# Altium schematic sheet MecanumTb66212.SchDoc
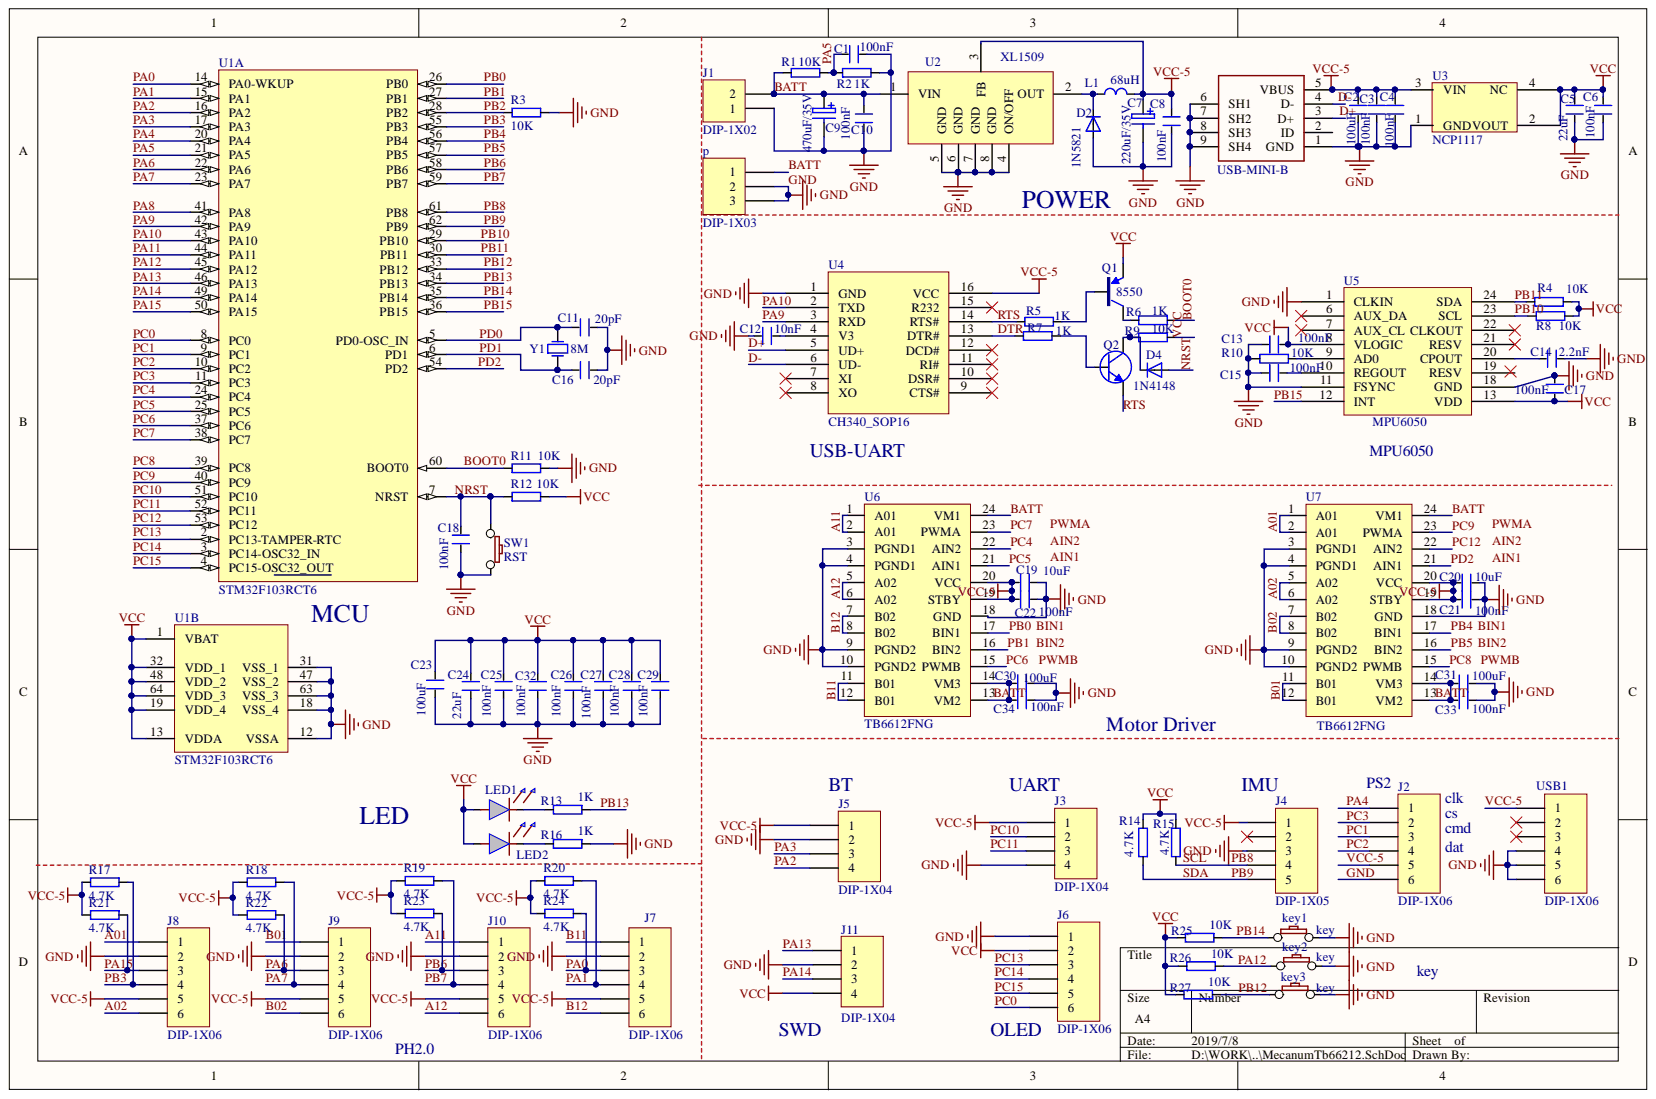
[diagram p0: MecanumTb66212.SchDoc]

## Parts
C1  100nF  [0603C]
C2  100uF  [1206C]
C3  100nF  [0603C]
C4  100nF  [0603C]
C5  22uF  [0805C]
C6  100nF  [0603C]
C7  220uF/35V  [C6.3X6.3]
C8  100nF  [0603C]
C9  470uF/35V  [C6.3X6.3]
C10  100nF  [0603C]
C11  20pF  [0603C]
C12  10nF  [0603C]
C13  100nF  [0603C]
C14  2.2nF  [0603C]
C15  100nF  [0603C]
C16  20pF  [0603C]
C17  100nF  [0603C]
C18  100nF  [0603C]
C19  10uF  [0603C]
C20  10uF  [0603C]
C21  100nF  [0603C]
C22  100nF  [0603C]
C23  100uF  [C6.3X6.3]
C24  22uF  [1206C]
C25  100nF  [0603C]
C26  100nF  [0603C]
C27  100nF  [0603C]
C28  100nF  [0603C]
C29  100nF  [0603C]
C30  100uF  [0805C]
C31  100uF  [0805C]
C32  100nF  [0603C]
C33  100nF  [0805C]
C34  100nF  [0805C]
D2  1N5821  [SMB]
D4  1N4148  [0603LED]
J1  DIP-1X02  [2PIN-5.08A]
J2  DIP-1X06  [HDR1X6]
J3  DIP-1X04  [HDR1X4]
J4  DIP-1X05  [DIP-1X05-2.54]
J5  DIP-1X04  [HDR1X4]
J6  DIP-1X06  [HDR1X6]
J7  DIP-1X06  [HDR1X6]
J8  DIP-1X06  [HDR1X6]
J9  DIP-1X06  [HDR1X6]
J10  DIP-1X06  [HDR1X6]
J11  DIP-1X04  [HDR1X4]
key1  key  [3.6*2.5-SWITCH]
key2  key  [3.6*2.5-SWITCH]
key3  key  [3.6*2.5-SWITCH]
L1  68uH  [L10X10]
LED1  RED_RX  [0603LED G]  (value not drawn)
LED2  RED_RX  [0603LED R]  (value not drawn)
p  DIP-1X03  [Power-Normal]
Q1  8550  [SOT23-3-TCM809]
Q2  8050  [AP2306]  (value not drawn)
R1  10K  [0603R]
R2  1K  [0603R]
R3  10K  [0603R]
R4  10K  [0603R]
R5  1K  [0603R]
R6  1K  [0603R]
R7  1K  [0603R]
R8  10K  [0603R]
R9  10K  [0603R]
R10  10K  [0603R]
R11  10K  [0603R]
R12  10K  [0603R]
R13  1K  [0603R]
R14  4.7K  [0603R]
R15  4.7K  [0603R]
R16  1K  [0603R]
R17  4.7K  [0603R]
R18  4.7K  [0603R]
R19  4.7K  [0603R]
R20  4.7K  [0603R]
R21  4.7K  [0603R]
R22  4.7K  [0603R]
R23  4.7K  [0603R]
R24  4.7K  [0603R]
R25  10K  [0603R]
R26  10K  [0603R]
R27  10K  [0603R]
SW1  RST  [3.6*2.5-SWITCH]
U1  STM32F103RCT6  [LC-LQFP-64_10x10x05P]  (parts A,B)
U2  XL1509  [SOP8]
U3  NCP1117  [SOT223-NCP1117]
U4  CH340_SOP16  [SOP16-MAX3232]
U5  MPU6050  [QFN24-MPU6050]
U6  TB6612FNG  [SSOP24]
U7  TB6612FNG  [SSOP24]
USB1  DIP-1X06  [DIP-1X06-2.54]
USB-MINI-B  USB-MINI-B  [USB-MINI-B]
Y1  8M  [OSC]

## Nets
A01: J8.1 U7.1(A01) U7.2(A01)
A02: J8.6 U7.5(A02) U7.6(A02)
A11: J10.1 U6.1(A01) U6.2(A01)
A12: J10.6 U6.5(A02) U6.6(A02)
B01: J9.1 U7.11(B01) U7.12(B01)
B02: J9.6 U7.7(B02) U7.8(B02)
B11: J7.1 U6.11(B01) U6.12(B01)
B12: J7.6 U6.7(B02) U6.8(B02)
BATT: C9.1 C10.2 C30.2 C31.2 C33.2 C34.2 J1.2 p.1 R1.1 U2.1(VIN) U6.13(VM2) U6.14(VM3) U6.24(VM1) U7.13(VM2) U7.14(VM3) U7.24(VM1)
BOOT0: R6.1 R11.1 U1.60(BOOT0)
D+: U4.5(UD+) USB-MINI-B.3(D+)
D-: U4.6(UD-) USB-MINI-B.4(D-)
DTR: R7.2 U4.13(DTR#)
GND: C1.1 C2.1 C3.1 C4.1 C5.1 C6.1 C7.2 C8.1 C9.2 C10.1 C11.1 C12.2 C13.2 C14.1 C15.2 C16.1 C17.2 C18.1 C19.1 C20.1 C21.1 C22.1 C23.2 C24.1 C25.1 C26.1 C27.1 C28.1 C29.1 C30.1 C31.1 C32.1 C33.1 C34.1 D2.1 J1.1 J2.6 J3.4 J4.3 J5.2 J6.1 J7.2 J8.2 J9.2 J10.2 J11.2 key1.2 key2.2 key3.2 p.2 p.3 R2.2 R3.1 R10.2 R11.2 R16.1 SW1.2 U1.12(VSSA) U1.18(VSS_4) U1.31(VSS_1) U1.47(VSS_2) U1.63(VSS_3) U2.4(ON/OFF) U2.5(GND) U2.6(GND) U2.7(GND) U2.8(GND) U3.1(GND) U4.1(GND) U5.1(CLKIN) U5.11(FSYNC) U5.18(GND) U6.3(PGND1) U6.4(PGND1) U6.9(PGND2) U6.10(PGND2) U6.18(GND) U7.3(PGND1) U7.4(PGND1) U7.9(PGND2) U7.10(PGND2) U7.18(GND) USB1.4 USB1.5 USB1.6 USB-MINI-B.1(GND) USB-MINI-B.6(SH1) USB-MINI-B.7(SH2) USB-MINI-B.8(SH3) USB-MINI-B.9(SH4)
NRST: C18.2 D4.1 R12.1 SW1.1 U1.7(NRST)
PA0: J7.3 R24.1 U1.14(PA0-WKUP)
PA1: J7.4 R20.1 U1.15(PA1)
PA2: J5.4 U1.16(PA2)
PA3: J5.3 U1.17(PA3)
PA4: J2.1 U1.20(PA4)
PA5: C1.2 R1.2 R2.1 U1.21(PA5)
PA6: J9.3 R22.1 U1.22(PA6)
PA7: J9.4 R18.1 U1.23(PA7)
PA8: U1.41(PA8)
PA9: U1.42(PA9) U4.3(RXD)
PA10: U1.43(PA10) U4.2(TXD)
PA11: U1.44(PA11)
PA12: key2.1 R26.1 U1.45(PA12)
PA13: J11.1 U1.46(PA13)
PA14: J11.3 U1.49(PA14)
PA15: J8.3 R21.1 U1.50(PA15)
PB0: U1.26(PB0) U6.17(BIN1)
PB1: U1.27(PB1) U6.16(BIN2)
PB2: R3.2 U1.28(PB2)
PB3: J8.4 R17.1 U1.55(PB3)
PB4: U1.56(PB4) U7.17(BIN1)
PB5: U1.57(PB5) U7.16(BIN2)
PB6: J10.3 R23.1 U1.58(PB6)
PB7: J10.4 R19.1 U1.59(PB7)
PB8: J4.4 R15.1 U1.61(PB8)
PB9: J4.5 R14.1 U1.62(PB9)
PB10: R8.2 U1.29(PB10) U5.23(SCL)
PB11: R4.2 U1.30(PB11) U5.24(SDA)
PB12: key3.1 R27.1 U1.33(PB12)
PB13: R13.1 U1.34(PB13)
PB14: key1.1 R25.1 U1.35(PB14)
PB15: U1.36(PB15) U5.12(INT)
PC0: J6.6 U1.8(PC0)
PC1: J2.3 U1.9(PC1)
PC2: J2.4 U1.10(PC2)
PC3: J2.2 U1.11(PC3)
PC4: U1.24(PC4) U6.22(AIN2)
PC5: U1.25(PC5) U6.21(AIN1)
PC6: U1.37(PC6) U6.15(PWMB)
PC7: U1.38(PC7) U6.23(PWMA)
PC8: U1.39(PC8) U7.15(PWMB)
PC9: U1.40(PC9) U7.23(PWMA)
PC10: J3.2 U1.51(PC10)
PC11: J3.3 U1.52(PC11)
PC12: U1.53(PC12) U7.22(AIN2)
PC13: J6.3 U1.2(PC13-TAMPER-RTC)
PC14: J6.4 U1.3(PC14-OSC32_IN)
PC15: J6.5 U1.4(PC15-OSC32_OUT)
PD0: C11.2 U1.5(PD0-OSC_IN) Y1.2
PD1: C16.2 U1.6(PD1) Y1.1
PD2: U1.54(PD2) U7.21(AIN1)
RTS: Q2.2 R5.2 U4.14(RTS#)
VCC: C5.2 C6.2 C13.1 C17.1 C23.1 C24.2 C25.2 C26.2 C27.2 C28.2 C29.2 C32.2 J6.2 J11.4 LED1.1 LED2.1 Q1.3 R4.1 R8.1 R9.1 R12.2 R14.2 R15.2 R25.2 R26.2 R27.2 U1.1(VBAT) U1.13(VDDA) U1.19(VDD_4) U1.32(VDD_1) U1.48(VDD_2) U1.64(VDD_3) U3.2(VOUT) U3.4(NC) U5.8(VLOGIC) U5.13(VDD)
VCC-5: C2.2 C3.2 C4.2 C7.1 C8.2 C19.2 C20.2 C21.2 C22.2 J2.5 J3.1 J4.1 J5.1 J7.5 J8.5 J9.5 J10.5 L1.2 R17.2 R18.2 R19.2 R20.2 R21.2 R22.2 R23.2 R24.2 U2.3(FB) U3.3(VIN) U4.16(VCC) U6.19(STBY) U6.20(VCC) U7.19(STBY) U7.20(VCC) USB1.1 USB-MINI-B.5(VBUS)
NetC12_1: C12.1 U4.4(V3)
NetC14_2: C14.2 U5.20(CPOUT)
NetC15_1: C15.1 U5.10(REGOUT)
NetD2_2: D2.2 L1.1 U2.2(OUT)
NetD4_2: D4.2 Q2.3 R9.2
NetLED1_2: LED1.2 R13.2
NetLED2_2: LED2.2 R16.2
NetQ1_1: Q1.1 R5.1
NetQ1_2: Q1.2 R6.2
NetQ2_1: Q2.1 R7.1
NetR10_1: R10.1 U5.9(AD0)
AIN1:
AIN2:
BIN1:
BIN2:
N00019:
PWMA:
PWMB:
VCC-5V:

Not connected: J4 2; U4 7(XI) 8(XO) 9(CTS#) 10(DSR#) 11(RI#) 12(DCD#) 15(R232); U5 6(AUX_DA) 7(AUX_CL) 19(RESV) 21(RESV) 22(CLKOUT); USB1 2 3; USB-MINI-B 2(ID)

## Library data of the parts
C1-C6 C8 C10-C34 = Cap: Capacitor; Component Kind: Standard; Library Name: accessory.SchLib
C7 C9 = CAP+: Component Kind: Standard; Library Name: accessory.SchLib
D2 D4 = DIODE: Component Kind: Standard; Library Name: accessory.SchLib
J1 = DIP-1X02: Header, 2-Pin; Component Kind: Standard; LatestRevisionDate: 17-Jul-2002; LatestRevisionNote: Re-released for DXP Platform.; Library Name: connector.SchLib; Publisher: Altium Limited
J2 J6-J10 USB1 = DIP-1X06: Header, 6-Pin; Component Kind: Standard; LatestRevisionDate: 17-Jul-2002; LatestRevisionNote: Re-released for DXP Platform.; Library Name: connector.SchLib; Signal Integrity: Connector; Publisher: Altium Limited
J3 J5 J11 = DIP-1X04: Header, 4-Pin; Component Kind: Standard; LatestRevisionDate: 17-Jul-2002; LatestRevisionNote: Re-released for DXP Platform.; Library Name: connector.SchLib; Signal Integrity: Connector; Publisher: Altium Limited
J4 = DIP-1X05: Header, 5-Pin; Component Kind: Standard; LatestRevisionDate: 17-Jul-2002; LatestRevisionNote: Re-released for DXP Platform.; Library Name: connector.SchLib; Signal Integrity: Connector; Publisher: Altium Limited
key1-key3 SW1 = BT: Component Kind: Standard; Library Name: accessory.SchLib
L1 = L: Inductor; Code_IEC: 1005; Value: 10mH; Code_JEITA: 0402; Component Kind: Standard; LatestRevisionDate: 29-May-2009; LatestRevisionNote: IPC-7351 Footprint Added.; PackageDescription: Chip Inductor; PackageReference: 0402-A; Published: 8-Jun-2000; Library Name: accessory.SchLib; Publisher: Altium Limited
LED1 LED2 = LED: Component Kind: Standard; Library Name: accessory.SchLib
p = DIP-1X03: Header, 3-Pin; Component Kind: Standard; LatestRevisionDate: 17-Jul-2002; LatestRevisionNote: Re-released for DXP Platform.; Library Name: connector.SchLib; Publisher: Altium Limited
Q1 = PNP: Component Kind: Standard; Library Name: accessory.SchLib
Q2 = NPN: Component Kind: Standard; Library Name: accessory.SchLib
R1-R27 = Res: Resistor; Component Kind: Standard; Library Name: accessory.SchLib
U1 = STM32F103RCT6: ARM; Component Kind: Standard; ComponentLink1Description: 供应商链接; ComponentLink1URL: http://www.szlcsc.com/product/details_8815.html; Library Name: MCU.SchLib; Package: LQFP-64_10x10x05P; Supplier: LC; SuppliersPartNumber: C8323
U6 U7 = TB6612: Component Kind: Standard; Library Name: chip1.SchLib
Y1 = OSC: Component Kind: Standard; Library Name: accessory.SchLib
U2 = XL1509: Component Kind: Standard; Library Name: chip1.SchLib
U3 = NCP1117: Component Kind: Standard; Library Name: chip1.SchLib
U4 = CH340_SOP16: Component Kind: Standard; Library Name: chip1.SchLib
U5 = MPU6050: Component Kind: Standard; Library Name: chip1.SchLib
USB-MINI-B = USB-MINI-B: Component Kind: Standard; Library Name: connector.SchLib
